# Altium schematic sheet Sheet2.SchDoc
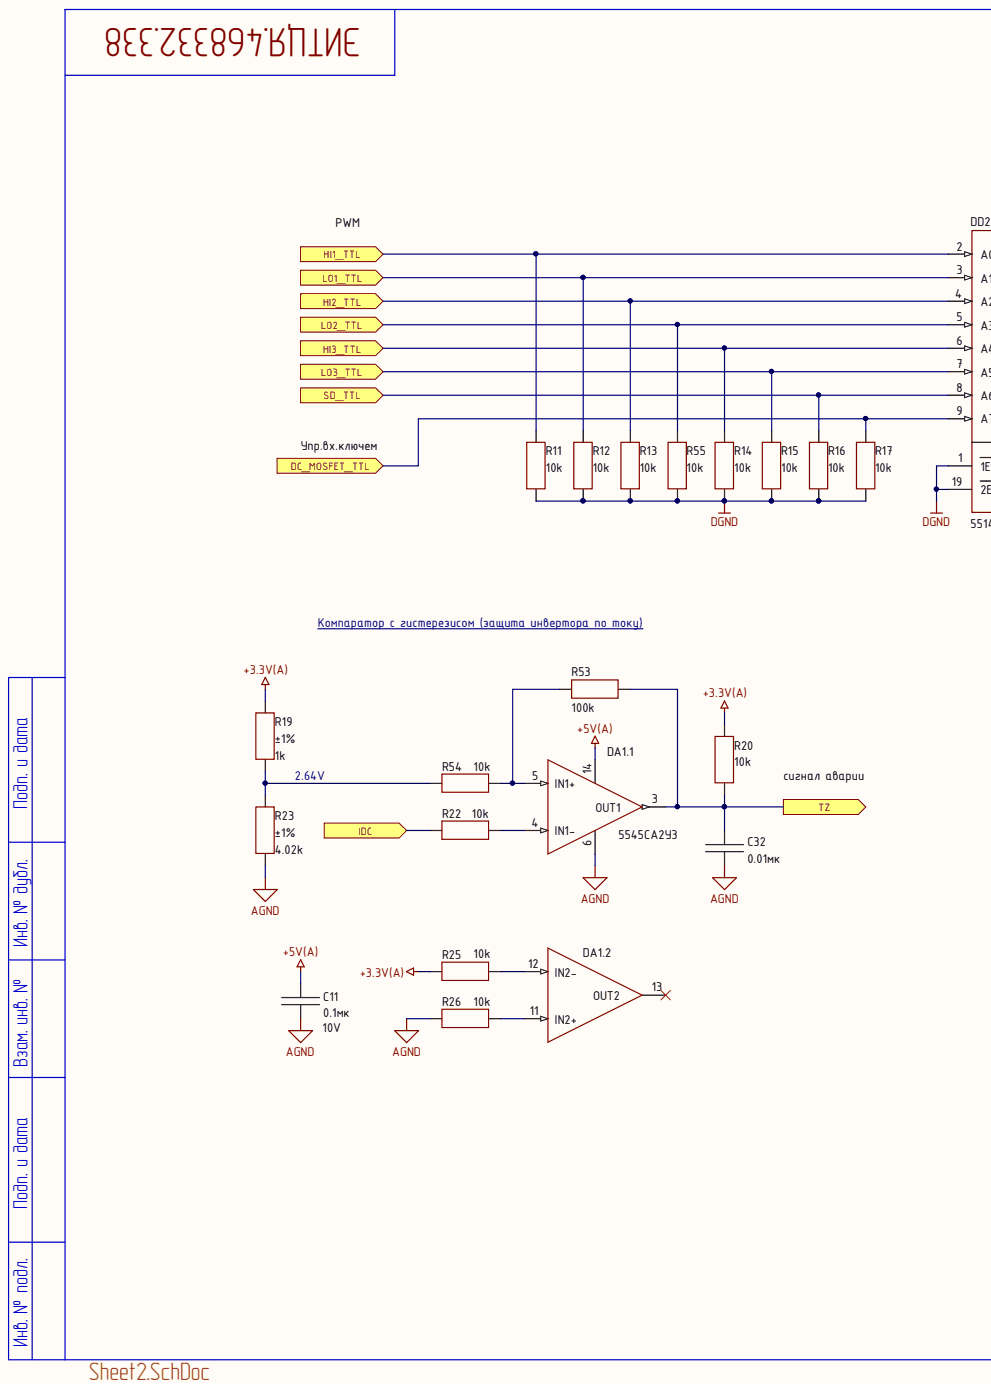
[diagram p0: Sheet2.SchDoc, left]
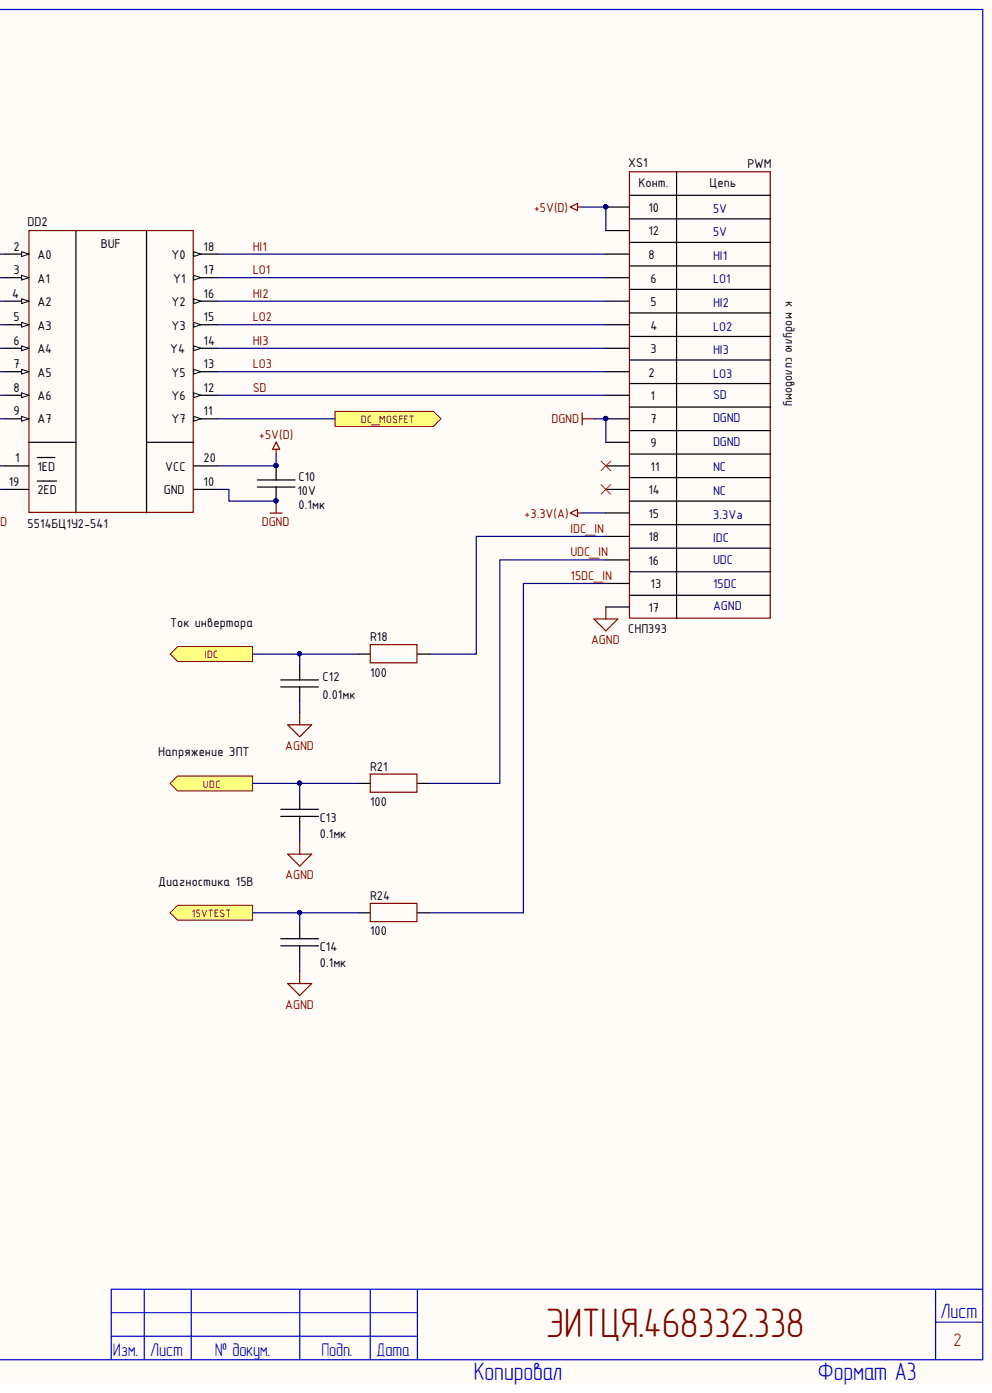
[diagram p1: Sheet2.SchDoc, right]

## Parts
C10  value 0.1мк  Voltage: 10V  [CAP: 0603P]  (hidden comment: Ceramic capacitor, 0.1мк, H90, +80-20%, 10V, 0603, -55...+125°C)
C11  value 0.1мк  Voltage: 10V  [CAP: 0603P]  (hidden comment: Ceramic capacitor, 0.1мк, H90, +80-20%, 10V, 0603, -55...+125°C)
C12  value 0.01мк  [CAP: 0603P]  (hidden comment: Ceramic capacitor, 0.01мк, H20, ±20%, 50V, 0603, -55...+125°C)
C13  value 0.1мк  [CAP: 0603P]  (hidden comment: Ceramic capacitor, 0.1мк, H90, +80-20%, 10V, 0603, -55...+125°C)
C14  value 0.1мк  [CAP: 0603P]  (hidden comment: Ceramic capacitor, 0.1мк, H90, +80-20%, 10V, 0603, -55...+125°C)
C32  value 0.01мк  [CAP: 0603P]  (hidden comment: Ceramic capacitor, 0.01мк, H20, ±20%, 50V, 0603, -55...+125°C)
DA1  IC comparator, Open Drain, от ± 1,5В до ± 16,5В, -60...+125°C, Н04.16-1В  [16-Н04.16-1B]  (value not drawn)  (parts .1,.2)
DD2  8 канальный буфер, 4.5..5.5V, -60...+125°C, 5121.20-A  [20-QLCC (5121.20-A)]  (value not drawn)
R11  value 10k  [RES: 0603P]  (hidden comment: Resistor film, 10k, ±5%, 0603, 0.1W, -55...+125°C)
R12  value 10k  [RES: 0603P]  (hidden comment: Resistor film, 10k, ±5%, 0603, 0.1W, -55...+125°C)
R13  value 10k  [RES: 0603P]  (hidden comment: Resistor film, 10k, ±5%, 0603, 0.1W, -55...+125°C)
R14  value 10k  [RES: 0603P]  (hidden comment: Resistor film, 10k, ±5%, 0603, 0.1W, -55...+125°C)
R15  value 10k  [RES: 0603P]  (hidden comment: Resistor film, 10k, ±5%, 0603, 0.1W, -55...+125°C)
R16  value 10k  [RES: 0603P]  (hidden comment: Resistor film, 10k, ±5%, 0603, 0.1W, -55...+125°C)
R17  value 10k  [RES: 0603P]  (hidden comment: Resistor film, 10k, ±5%, 0603, 0.1W, -55...+125°C)
R18  value 100  [RES: 0603P]  (hidden comment: Resistor film, 100, ±5%, 0603, 0.1W, -55...+125°C)
R19  value 1k  Tolerance: ±1%  [RES: 0603P]  (hidden comment: Resistor film, 1k, ±1%, 0603, 0.1W, -55...+125°C)
R20  value 10k  [RES: 0603P]  (hidden comment: Resistor film, 10k, ±5%, 0603, 0.1W, -55...+125°C)
R21  value 100  [RES: 0603P]  (hidden comment: Resistor film, 100, ±5%, 0603, 0.1W, -55...+125°C)
R22  value 10k  [RES: 0603P]  (hidden comment: Resistor film, 10k, ±5%, 0603, 0.1W, -55...+125°C)
R23  value 4.02k  Tolerance: ±1%  [RES: 0603P]  (hidden comment: Resistor film, 4.02k, ±1%, 0603, 0.1W, -55...+125°C)
R24  value 100  [RES: 0603P]  (hidden comment: Resistor film, 100, ±5%, 0603, 0.1W, -55...+125°C)
R25  value 10k  [RES: 0603P]  (hidden comment: Resistor film, 10k, ±5%, 0603, 0.1W, -55...+125°C)
R26  value 10k  [RES: 0603P]  (hidden comment: Resistor film, 10k, ±5%, 0603, 0.1W, -55...+125°C)
R53  value 100k  [RES: 0603P]  (hidden comment: Resistor film, 100k, ±5%, 0603, 0.1W, -55...+125°C)
R54  value 10k  [RES: 0603P]  (hidden comment: Resistor film, 10k, ±5%, 0603, 0.1W, -55...+125°C)
R55  value 10k  [RES: 0603P]  (hidden comment: Resistor film, 10k, ±5%, 0603, 0.1W, -55...+125°C)
XS1  CommentText: СНП393  [CON: PBD-18]  (hidden comment: Connector, 18pin, 2.54mm, 500VAC, 3A)

## Ports
15VTEST, DC_MOSFET, DC_MOSFET_TTL, HI1_TTL, HI2_TTL, HI3_TTL, IDC, LO1_TTL, LO2_TTL, LO3_TTL, SD_TTL, TZ, UDC

## Nets
+3.3V(A): R19.1 R20.1 R25.2 XS1.15
+5V(A): C11.2 DA1.14
+5V(D): C10.2 DD2.20(VCC) XS1.10 XS1.12
AGND: C11.1 C12.2 C13.1 C14.1 C32.2 DA1.6 R23.2 R26.2 XS1.17
DGND: C10.1 DD2.1(1ED) DD2.10(GND) DD2.19(2ED) R11.2 R12.2 R13.2 R14.2 R15.2 R16.2 R17.2 R55.2 XS1.7 XS1.9
15DC_IN: R24.1 XS1.13
15VTEST: C14.2 R24.2 ; port 15VTEST
DC_MOSFET: DD2.11(Y7) ; port DC_MOSFET
DC_MOSFET_TTL: DD2.9(A7) R17.1 ; port DC_MOSFET_TTL
HI1: DD2.18(Y0) XS1.8
HI1_TTL: DD2.2(A0) R11.1 ; port HI1_TTL
HI2: DD2.16(Y2) XS1.5
HI2_TTL: DD2.4(A2) R13.1 ; port HI2_TTL
HI3: DD2.14(Y4) XS1.3
HI3_TTL: DD2.6(A4) R14.1 ; port HI3_TTL
IDC: C12.1 R18.2 R22.1 ; port IDC
IDC_IN: R18.1 XS1.18
LO1: DD2.17(Y1) XS1.6
LO1_TTL: DD2.3(A1) R12.1 ; port LO1_TTL
LO2: DD2.15(Y3) XS1.4
LO2_TTL: DD2.5(A3) R55.1 ; port LO2_TTL
LO3: DD2.13(Y5) XS1.2
LO3_TTL: DD2.7(A5) R15.1 ; port LO3_TTL
SD: DD2.12(Y6) XS1.1
SD_TTL: DD2.8(A6) R16.1 ; port SD_TTL
TZ: C32.1 DA1.3(OUT1) R20.2 R53.2 ; port TZ
UDC: C13.2 R21.2 ; port UDC
UDC_IN: R21.1 XS1.16
NetDA1_4: DA1.4(IN1-) R22.2
NetDA1_5: DA1.5(IN1+) R53.1 R54.2
NetDA1_11: DA1.11(IN2+) R26.1
NetDA1_12: DA1.12(IN2-) R25.1
NetR19_2: R19.2 R23.1 R54.1

Not connected: DA1 13(OUT2); XS1 11 14

## Library data of the parts
C10 C11 C13 C14 = Ceramic_0603: Manufacturer: Гириконд; PartNumber: К10-79-10В-0,1 мкФ +80-20% H90; MAX: 00131675; Component Kind: Standard; HelpURL: http://www.giricond.ru/files/k10-79_vp_2018_saiyt.pdf; Library Name: Capacitor.SchLib; Package: 0603; Temperature: -55...+125°C; Tolerance: +80-20%; TypeCapacitor: Ceramic capacitor; TypeDielectric: H90; ValueManufacturerPartNumber: Ceramic capacitor, 0.1мк, H90, +80-20%, 10V, 0603, -55...+125°C
  C13 C14: Voltage: 10V
C12 C32 = Ceramic_0603: Manufacturer: Монолит; PartNumber: К10-84в-1608М-50В-0.01мк ±20%-Н20-N-A; Analog: MAX 00131676 (pin-to-pin); Component Kind: Standard; HelpURL: http://www.monolit.vitebsk.by/Files/K10-84.pdf; Library Name: Capacitor.SchLib; Package: 0603; Temperature: -55...+125°C; Tolerance: ±20%; TypeCapacitor: Ceramic capacitor; TypeDielectric: H20; ValueManufacturerPartNumber: Ceramic capacitor, 0.01мк, H20, ±20%, 50V, 0603, -55...+125°C; Voltage: 50V
DA1 = 5545СА2У3: Comparator USSR; Manufacturer: НПП ВОСТОК; Analog: LM2903D; TY: АЕЯР.431350.632-02 ТУ; Component Kind: Standard; ComponentType: IC comparator; HelpURL: http://www.vostok.nsk.su/files/pdf/152181836987_5545ca2u3.pdf; Library Name: IC. Comparator.SchLib; OutputType: Open Drain; Package: Н04.16-1В; PartNumber: 5545СА2У3; Supply: от ± 1,5В до ± 16,5В; Temperature: -60...+125°C; ValueManufacturerPartNumber: IC comparator, Open Drain, от ± 1,5В до ± 16,5В, -60...+125°C, Н04.16-1В
DD2 = 5514БЦ1У2-541: USSR Buffer QFN; Manufacturer: Анстрем; Analog: функц.-й аналог 74(54)HC 541; Component Kind: Standard; ComponentType: 8 канальный буфер; Library Name: IC. Logic.SchLib; Package: 5121.20-A; PartNumber: 5514БЦ1У2-541; Supply: 4.5..5.5V; Temperature: -60...+125°C; ValueManufacturerPartNumber: 8 канальный буфер, 4.5..5.5V, -60...+125°C, 5121.20-A
R11-R17 R20 R22 R25 R26 R54 R55 = Resistor_0603: Manufacturer: ОАО Ресурс; PartNumber: Р1-12-0,1ум.-10~кОм ±5%-М ШКАБ.434110.002 ТУ; Analog: MAX 00133986 (1%); MAX: 00133985; Component Kind: Standard; HelpURL: https://aoresurs.com/catalog/chip_rezistory/rezistory-r1-12-kategoriya-kachestva-vp-/; Library Name: Resistor.IntLib; Package: 0603; Power: 0.1W; Temperature: -55...+125°C; Tolerance: ±5%; TypeResistor: Resistor film; ValueManufacturerPartNumber: Resistor film, 10k, ±5%, 0603, 0.1W, -55...+125°C
R18 R21 R24 = Resistor_0603: Manufacturer: ОАО Ресурс; PartNumber: Р1-12-0,1ум.-100~Ом ±5%-М ШКАБ.434110.002 ТУ; MAX: 00133991; Component Kind: Standard; HelpURL: https://aoresurs.com/catalog/chip_rezistory/rezistory-r1-12-kategoriya-kachestva-vp-/; Library Name: Resistor.IntLib; Package: 0603; Power: 0.1W; Temperature: -55...+125°C; Tolerance: ±5%; TypeResistor: Resistor film; ValueManufacturerPartNumber: Resistor film, 100, ±5%, 0603, 0.1W, -55...+125°C
R19 = Resistor_0603: Manufacturer: ОАО Ресурс; PartNumber: Р1-12-0,1ум.-1~кОм ±1%-М ШКАБ.434110.002 ТУ; MAX: 00133971; Component Kind: Standard; HelpURL: https://aoresurs.com/catalog/chip_rezistory/rezistory-r1-12-kategoriya-kachestva-vp-/; Library Name: Resistor.IntLib; Package: 0603; Power: 0.1W; Temperature: -55...+125°C; TypeResistor: Resistor film; ValueManufacturerPartNumber: Resistor film, 1k, ±1%, 0603, 0.1W, -55...+125°C
R23 = Resistor_0603: Manufacturer: ОАО Ресурс; PartNumber: Р1-12-0,1ум.-4.02~кОм ±1%-М ШКАБ.434110.002 ТУ; MAX: 00134054; Component Kind: Standard; HelpURL: https://aoresurs.com/catalog/chip_rezistory/rezistory-r1-12-kategoriya-kachestva-vp-/; Library Name: Resistor.IntLib; Package: 0603; Power: 0.1W; Temperature: -55...+125°C; TypeResistor: Resistor film; ValueManufacturerPartNumber: Resistor film, 4.02k, ±1%, 0603, 0.1W, -55...+125°C
R53 = Resistor_0603: Manufacturer: ОАО Ресурс; PartNumber: Р1-12-0,1ум.-100~кОм ±5%-М ШКАБ.434110.002 ТУ; Analog: MAX 00122851; MAX: 00133990; Component Kind: Standard; HelpURL: https://aoresurs.com/catalog/chip_rezistory/rezistory-r1-12-kategoriya-kachestva-vp-/; Library Name: Resistor.IntLib; Package: 0603; Power: 0.1W; Temperature: -55...+125°C; Tolerance: ±5%; TypeResistor: Resistor film; ValueManufacturerPartNumber: Resistor film, 100k, ±5%, 0603, 0.1W, -55...+125°C
XS1 = PBD-18: Manufacturer: Электродеталь; PartNumber: СНП393-18РП21-2 РЮМК.430420.035 ТУ; Analog: MAX 00135030 с доработкой до 18-pin; Component Kind: Standard; CurrentMax: 3A; HelpURL: https://www.elektrodetal.com/catalog/snp393/; Library Name: Connector.SchLib; Multichannel: 18pin; Pitch: 2.54mm; TypeConnector: Connector; ValueManufacturerPartNumber: Connector, 18pin, 2.54mm, 500VAC, 3A; VoltageMax: 500VAC
